# Altium schematic sheet JacdacServoDual 23.SchDoc
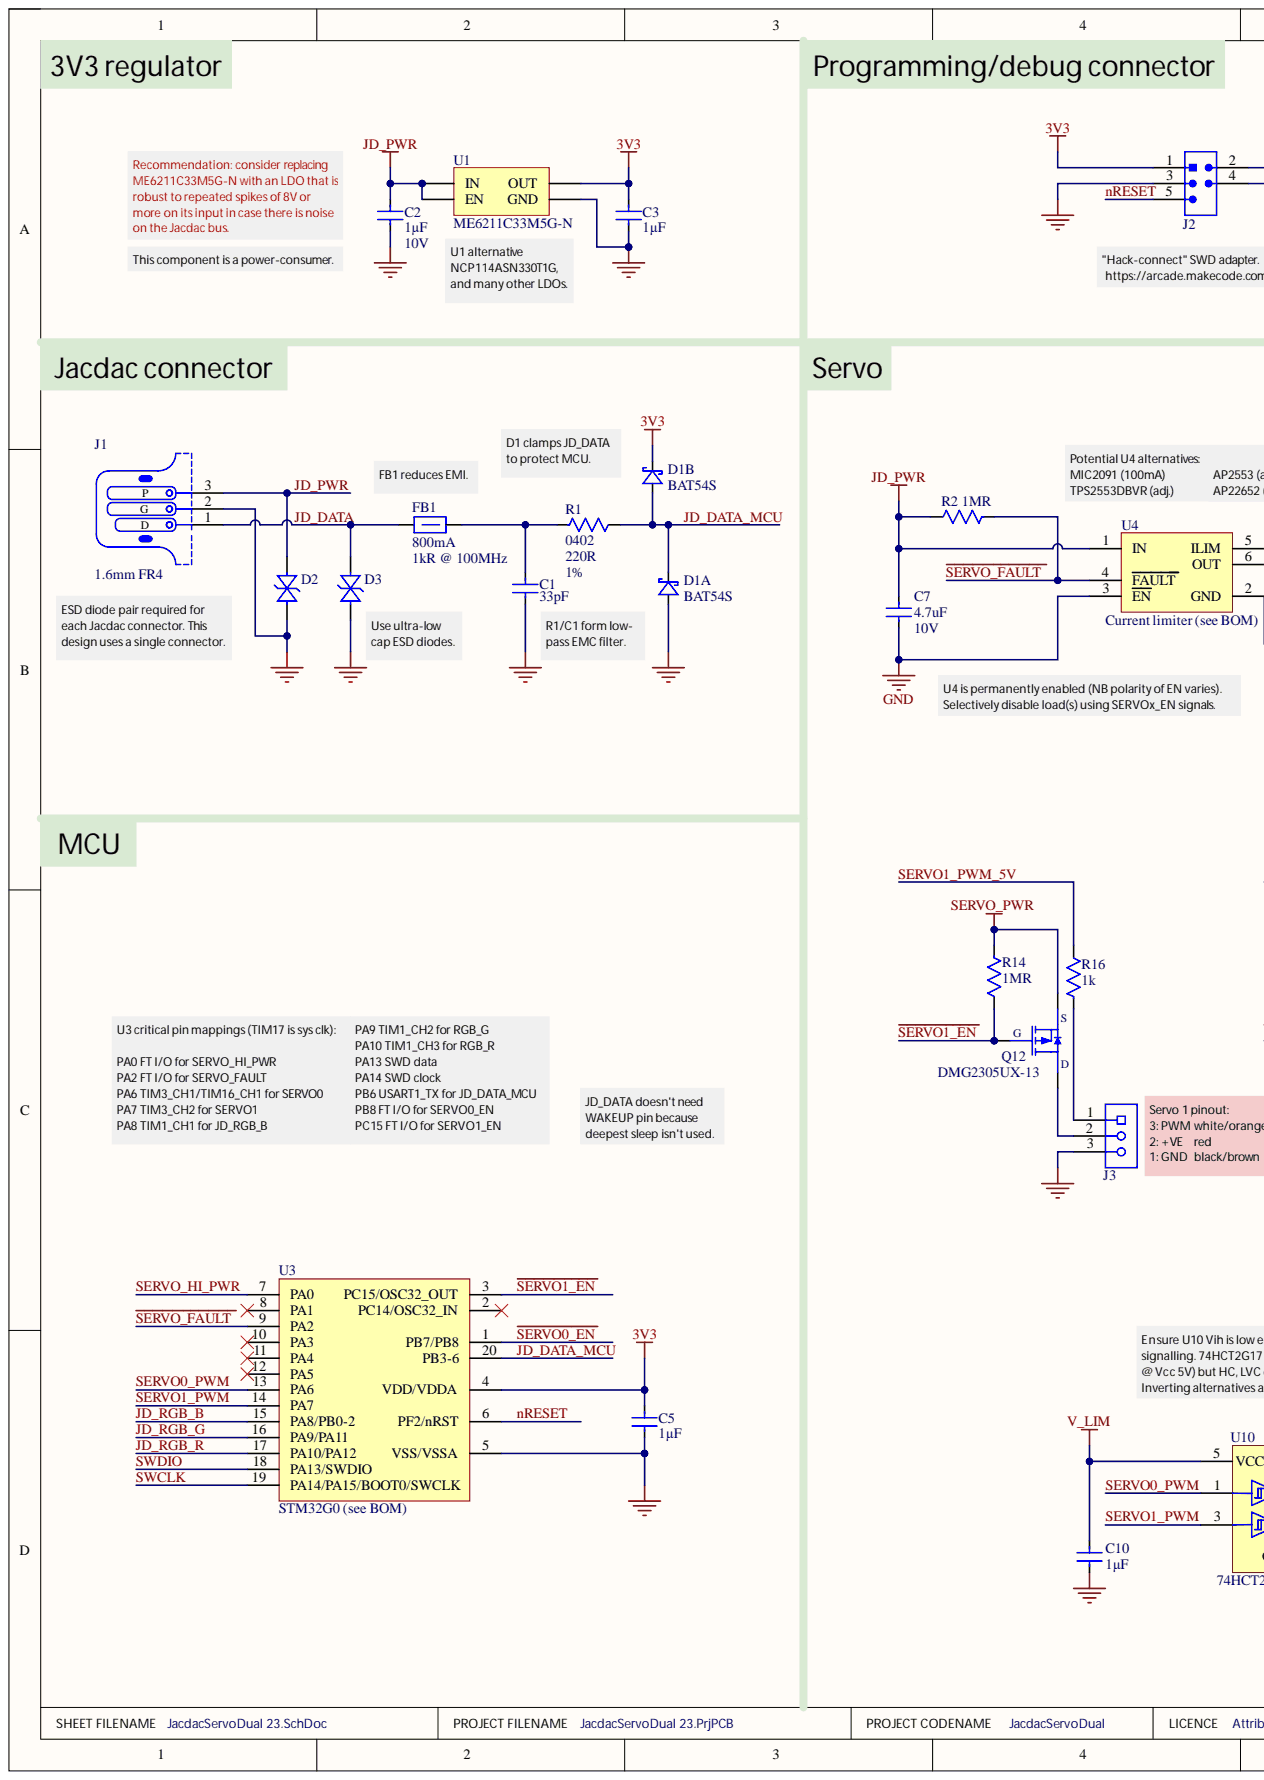
[diagram p0: JacdacServoDual 23.SchDoc, left]
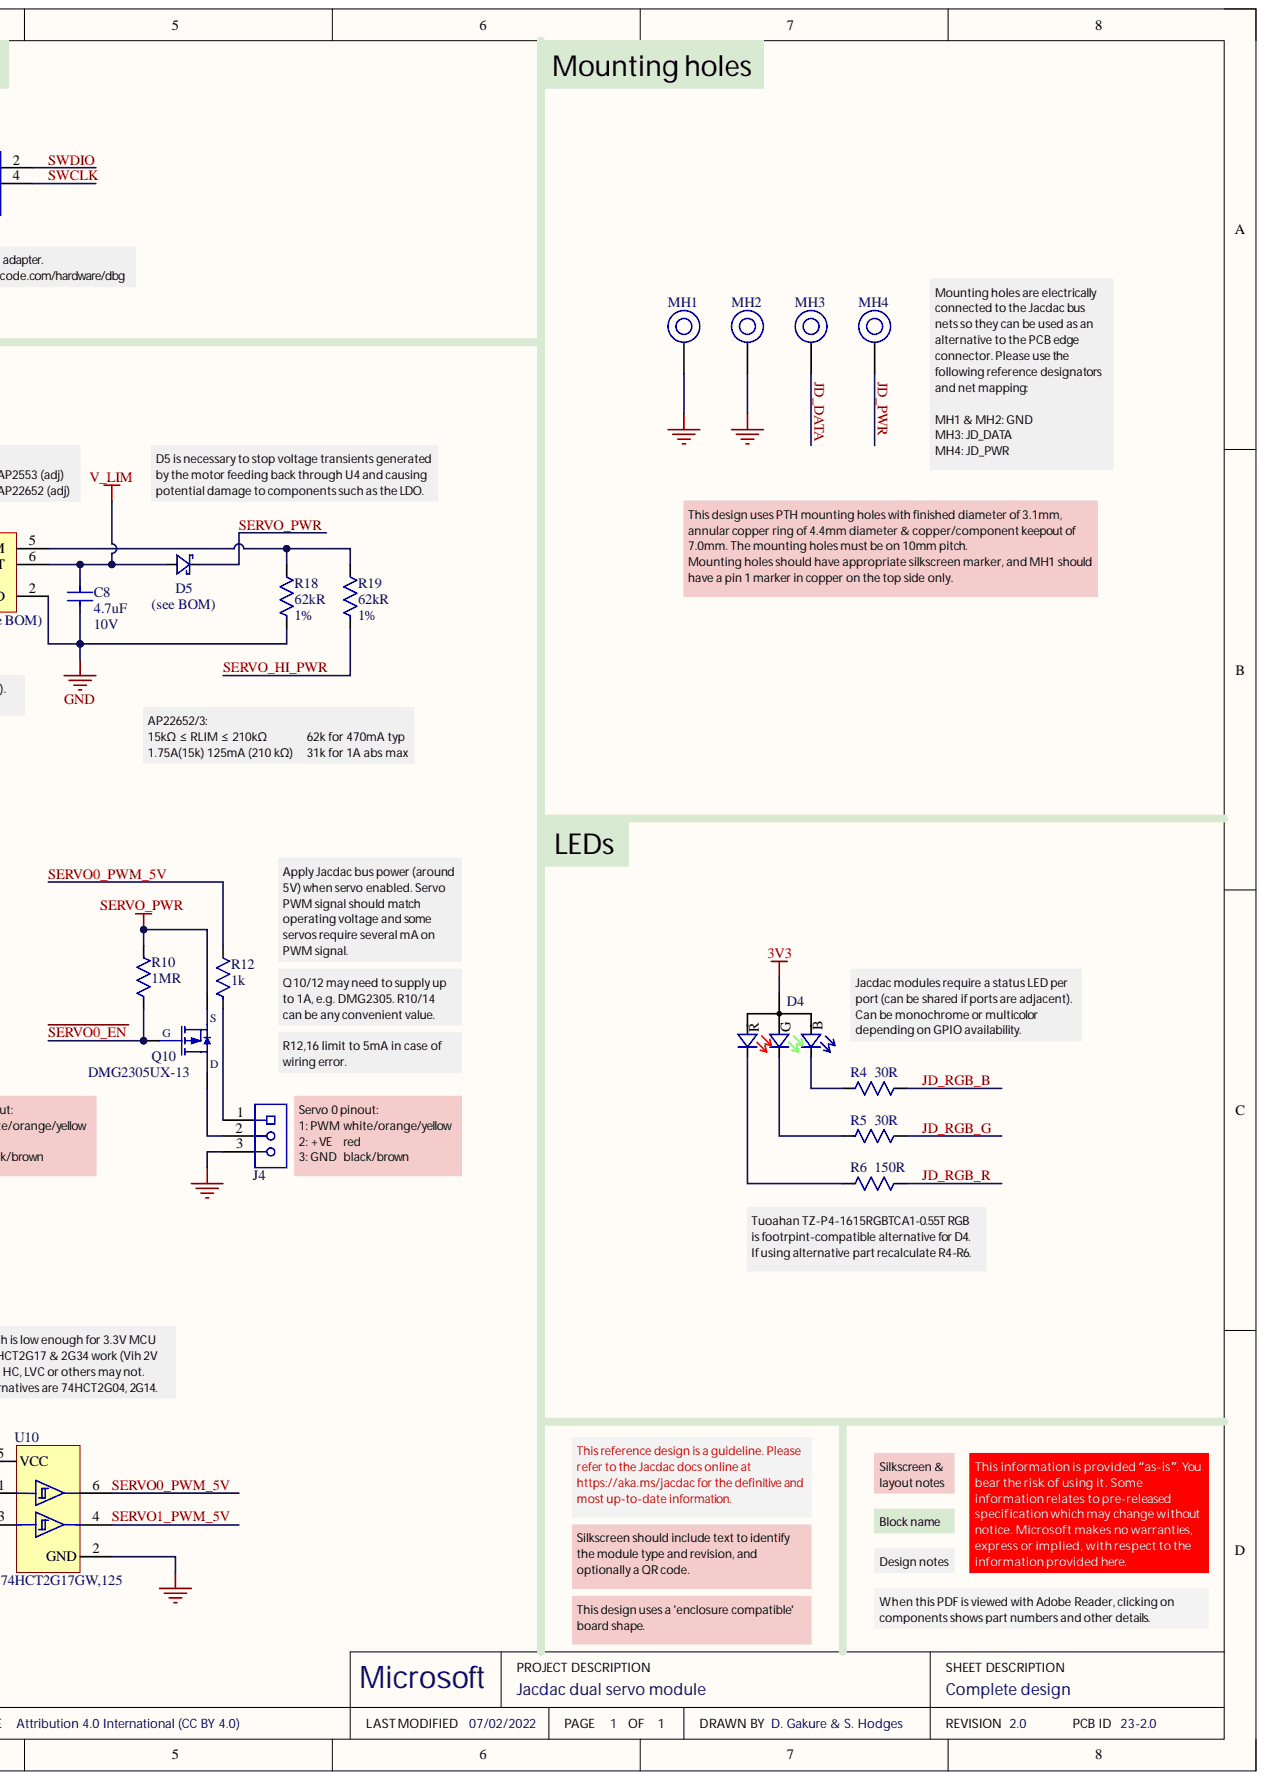
[diagram p1: JacdacServoDual 23.SchDoc, right]

## Parts
C1  Capacitance: 33pF
C2  Capacitance: 1µF  Voltage Rating: 10V
C3  Capacitance: 1µF
C5  Capacitance: 1µF
C7  Capacitance: 4.7uF  Voltage Rating: 10V
C8  Capacitance: 4.7uF  Voltage Rating: 10V
C10  Capacitance: 1µF
D1  Generic Part Number: BAT54S  (parts A,B)
D2  (no value)
D3  (no value)
D4  (no value)
D5  Generic Part Number: (see BOM)
FB1  Current Rating: 800mA
J1  PCB Type: 1.6mm FR4
J2  (no value)
J3  (no value)
J4  (no value)
MH1  (no value)
MH2  (no value)
MH3  (no value)
MH4  (no value)
Q10  Manufacturer Part Number: DMG2305UX-13
Q12  Manufacturer Part Number: DMG2305UX-13
R1  Case Code (Imperial): 0402  Resistance: 220R
R2  Resistance: 1MR
R4  Resistance: 30R
R5  Resistance: 30R
R6  Resistance: 150R
R10  Resistance: 1MR
R12  Resistance: 1k
R14  Resistance: 1MR
R16  Resistance: 1k
R18  Resistance: 62kR  Resistance Tolerance: 1%
R19  Resistance: 62kR  Resistance Tolerance: 1%
U1  Manufacturer Part Number: ME6211C33M5G-N
U3  Generic Part Number: STM32G0 (see BOM)
U4  Generic Part Number: Current limiter (see BOM)
U10  Manufacturer Part Number 1: 74HCT2G17GW,125

## Nets
3V3: C3.2 C5.2 D1.2 D4.1 J2.1 U1.5(OUT) U3.4(VDD/VDDA)
GND: C1.1 C2.1 C3.1 C5.1 C7.1 C8.1 C10.1 D1.1 D2.2 D3.2 J1.2 J2.3 J3.3 J4.3 MH1.1 MH2.1 R18.1 U1.2(GND) U3.5(VSS/VSSA) U4.2(GND) U4.3(EN) U10.2(GND)
V_LIM: C8.2 C10.2 D5.2 U4.6(OUT) U10.5(VCC)
~{SERVO0_EN}: Q10.1 R10.1 U3.1(PB7/PB8)
~{SERVO1_EN}: Q12.1 R14.1 U3.3(PC15/OSC32_OUT)
~{SERVO_FAULT}: R2.2 U3.9(PA2) U4.4(FAULT)
JD_DATA: D3.1 FB1.1 J1.1 MH3.1
JD_DATA_MCU: D1.3 R1.1 U3.20(PB3-6)
JD_PWR: C2.2 C7.2 D2.1 J1.3 MH4.1 R2.1 U1.1(IN) U1.3(EN) U4.1(IN)
JD_RGB_B: R4.2 U3.15(PA8/PB0-2)
JD_RGB_G: R5.2 U3.16(PA9/PA11)
JD_RGB_R: R6.2 U3.17(PA10/PA12)
nRESET: J2.5 U3.6(PF2/nRST)
SERVO0_PWM: U3.13(PA6) U10.1
SERVO0_PWM_5V: R12.1 U10.6
SERVO1_PWM: U3.14(PA7) U10.3
SERVO1_PWM_5V: R16.1 U10.4
SERVO_HI_PWR: R19.1 U3.7(PA0)
SERVO_PWR: D5.1 Q10.2 Q12.2 R10.2 R14.2
SWCLK: J2.4 U3.19(PA14/PA15/BOOT0/SWCLK)
SWDIO: J2.2 U3.18(PA13/SWDIO)
NetC1_2: C1.2 FB1.2 R1.2
NetD4_2: D4.2 R6.1
NetD4_3: D4.3 R5.1
NetD4_4: D4.4 R4.1
NetJ3_1: J3.1 R16.2
NetJ3_2: J3.2 Q12.3
NetJ4_1: J4.1 R12.2
NetJ4_2: J4.2 Q10.3
NetR18_2: R18.2 R19.2 U4.5(ILIM)

Not connected: U3 2(PC14/OSC32_IN) 8(PA1) 10(PA3) 11(PA4) 12(PA5)

## Library data of the parts
C1 = (no symbol name): CAP CER 33PF 50V C0G/NP0 0402; Manufacturer: Samsung; Manufacturer Part Number: CL05C330JB5NNNC; Dielectric: C0G; Material: Ceramic; Temperature Coefficient: 300ppm/°C; Tolerance: 5%; Case Code (Imperial): 0402; Case Code (Metric): 1005; Case/Package: 0402; Category: Ceramic Capacitors; ComponentLink1Description: Online datasheet; ComponentLink1URL: https://datasheet.ciiva.com/15195/getdatasheetpartid-355282-15195687.pdf; Construction: Flat; Contact Plating: Tin; Max Operating Temperature: 125°C; Min Operating Temperature: -55°C; RoHS Compliant: Yes; Series: CL; Supplier 1: Digi-Key; Supplier 2: Newark; Supplier 3: Mouser; Supplier 4: Farnell; Supplier Part Number 1: 1276-1272-1-ND; Supplier Part Number 2: 82AC9259; Supplier Part Number 3: 187-CL05C330JB5NNNC; Supplier Part Number 4: 3013373RL; Voltage: 50V; Voltage Rating: 50V; Voltage Rating (DC): 50V
C2 C3 C5 C10 = (no symbol name): CAP CER 1UF 10V X5R 0402; Manufacturer: Samsung; Manufacturer Part Number: CL05A105KP5NNNC; Material: Ceramic; Tolerance: 10%; Case Code (Imperial): 0402; Case Code (Metric): 1005; Case/Package: 0402; Category: Capacitors; ComponentLink1Description: Online datasheet; ComponentLink1URL: https://datasheet.ciiva.com/15195/getdatasheetpartid-358390-15195630.pdf; Contact Plating: Tin; Dielectric: X5R; Max Operating Temperature: 85°C; Min Operating Temperature: -55°C; Supplier 1: Digi-Key; Supplier 2: Mouser; Supplier 3: Farnell; Supplier Part Number 1: 1276-1076-1-ND; Supplier Part Number 2: 187-CL05A105KP5NNNC; Supplier Part Number 3: 3013329
  C3 C5 C10: Voltage Rating: 10V
C7 C8 = (no symbol name): CAP CER 4.7UF 10V X5R 0402; Manufacturer: Samsung; Manufacturer Part Number: CL05A475MP5NRNC; Tolerance: 20%; Case Code (Imperial): 0402; Case Code (Metric): 1005; Case/Package: 0402; Category: Ceramic Capacitors; ComponentLink1Description: Online datasheet; ComponentLink1URL: https://datasheet.ciiva.com/25786/cl05a475mp5nrnc-25786963.pdf; Dielectric: X5R; Max Operating Temperature: 85°C; Min Operating Temperature: -55°C; RoHS Compliant: Yes; Series: CL; Supplier 1: Digi-Key; Supplier 2: Future Electronics; Supplier Part Number 1: 1276-1482-2-ND; Supplier Part Number 2: 2103694
D1 = (no symbol name): DIODE ARRAY SCHOTTKY 30V SOT23; Manufacturer: Nexperia; Manufacturer Part Number: BAT54S,235; Category: Rectifier Diodes; ComponentLink1Description: NXP datasheet online; ComponentLink1URL: https://assets.nexperia.com/documents/data-sheet/BAT54_SER.pdf; Supplier 1: Digi-Key; Supplier 2: Mouser; Supplier 3: RSComponents; Supplier Part Number 1: 1727-1868-1-ND; Supplier Part Number 2: 771-BAT54S235; Supplier Part Number 3: 1707990
D2 D3 = (no symbol name): Bidirectional 5V (Max) 6V 16V 3.5A (8/20us) 0402 TVS RoHS; Manufacturer: Born Semiconductor; Manufacturer Part Number: RCLAMP0521P-N; ComponentLink1Description: Online datasheet; ComponentLink1URL: https://datasheet.lcsc.com/szlcsc/Bourne-Semicon-Shenzhen-RCLAMP0521P-N_C316043.pdf; Package: 0402; Supplier1: LCSC; Supplier1 Part Number: C316043
D4 = (no symbol name): Red, Green, Blue (RGB) 626nm Red, 525nm Green, 470nm Blue LED Indication - Discrete 1.9V Red, 3.4V Green, 3.4V Blue 0606 (1616 Metric); Manufacturer: Broadcom Avago; Manufacturer Part Number: HSMF-C114; Color: Blue,Green,Red; Forward Current: 20mA; Lens Color: White; Lens Style: Diffused,Rectangular; Lens Transparency: Diffused; Luminous Intensity: 70mcd; Number of Elements: 3; Power Rating: 78mW; Reverse Voltage: 5V; Viewing Angle: 145°; Case Code (Imperial): 0606; Case Code (Metric): 1616; Case/Package: 0606; Category: Optoelectronics; ComponentLink1Description: Online datasheet; ComponentLink1URL: https://docs.broadcom.com/docs/AV02-2451EN; Dominant Wavelength: 470nm; Forward Voltage: 3.4V; Max Operating Temperature: 85°C; Min Operating Temperature: -40°C; Number of LEDs: 3; Number of Pins: 4; Supplier 1: Digi-Key; Supplier 2: Farnell; Supplier 3: Mouser; Supplier Part Number 1: 516-1795-1-ND; Supplier Part Number 2: 1058362; Supplier Part Number 3: 630-HSMF-C114; Supplier Part Number 10: ?; Test Current: 20mA; Wavelength: 470nm
D5 = (no symbol name): DIODE SCHOTTKY 20V 1A SOD123FL; Manufacturer: ON Semiconductor; Manufacturer Part Number: MBR120VLSFT3G; Element Configuration: Single; Forward Voltage: 340mV; Max Forward Surge Current (Ifsm): 45A; Max Reverse Leakage Current: 600uA; Polarity: Standard; Average Rectified Current: 1A; Category: Schottky Diodes; ComponentLink4Description: On Semi datasheet online; ComponentLink4URL: https://datasheet.ciiva.com/6380/mbr120vlsft1-d-6380910.pdf; Current Rating: 1A; Forward Current: 1A; Halogen Free: Halogen Free; Max Operating Temperature: 125°C; Max Repetitive Reverse Voltage (Vrrm): 20V; Max Reverse Voltage (DC): 20V; Max Surge Current: 45A; Min Operating Temperature: -65°C; Number of Pins: 2; Output Current: 1A; Peak Non-Repetitive Surge Current: 45A; Peak Reverse Current: 600uA; Supplier 1: Digi-Key; Supplier 2: Mouser; Supplier 3: RSComponents; Supplier Part Number 1: MBR120VLSFT3GOSCT-ND; Supplier Part Number 2: 863-MBR120VLSFT3G; Supplier Part Number 3: 1867479; Voltage Rating (DC): 20V
FB1 = (no symbol name): 0603 1k@100MHz 0.8A chip; Manufacturer: TDK; Manufacturer Part Number: MPZ1608S102ATA00; Core Material: Ferrite; DC Resistance (DCR): 300mR; Impedance: 1kR @ 100MHz; Tolerance: 25%; Case Code (Imperial): 0603; Case Code (Metric): 1608; Case/Package: 0603; ComponentLink2Description: datasheet online; ComponentLink2URL: https://www.mouser.co.uk/datasheet/2/400/eads_commercial_power_mpz1608_en-923650.pdf; ComponentLink3Description: datasheet; Max DC Current: 800mA; Supplier 1: Digi-Key; Supplier 2: Mouser; Supplier Part Number 1: 445-8694-1-ND; Supplier Part Number 2: 810-MPZ1608S102ATA00; ComponentLink3URL: Y:\System\Altium\Libraries 2014\TDK\Datasheets\eads_commercial_power_mpz1608_en-923650.pdf
J1 = (no symbol name): 3 pin Jacdac edge connector 1.27mm pitch; Manufacturer Part Number: JACDAC_EDGE_CONNECTOR; ComponentLink1Description: Yuliang JACDAC cable connector drawing online; ComponentLink1URL: https://github.com/microsoft/jacdac-mdk/blob/main/connector/JACDAC-Connector-by-Yuliang-2020-11-28.pdf; ComponentLink2Description: JACDAC PCB edge connector spec onlin; ComponentLink2URL: https://github.com/microsoft/jacdac-mdk/blob/main/connector/JACDAC_PCB_Edge_Connector_Drawing_JD-PEC-01_rev_01.pdf
J2 = (no symbol name): Hack Connect Mini 1.27 mm pitch 2-row
J3 J4 = (no symbol name): SAMTEC         TSM-103-01-L-SH-A             Board-To-Board Connector, TSM Series, 3 Contacts, Header, 2.54 mm, Surface Mount, 1 Rows; Gender: Male; Number of Contacts: 3; Pitch: 2.54mm; ComponentLink2Description: part of technical drawing online; ComponentLink2URL: https://datasheet.ciiva.com/25616/0900766b8115801b-25616876.pdf; ComponentLink3Description: part of technical drawing; ComponentLink4Description: samtec datasheet; ComponentLink5Description: samtec datasheet online; ComponentLink5URL: https://uk.rs-online.com/webdocs/1157/0900766b81157e6c.pdf?src-supplier=RSComponents; Contact Plating: Gold; Manufacturer: Samtec; Manufacturer Part Number: TSM-103-01-L-SH-A; Number of Rows: 1; Supplier 1: Digi-Key; Supplier 2: RSComponents; Supplier 3: Mouser; Supplier Part Number 1: SAM12221-ND; Supplier Part Number 2: 2001543; Supplier Part Number 3: 200-TSM10301LSHA; Termination: Solder; ComponentLink3URL: Y:\System\Altium\Libraries 2014\Samtec\Datasheets\TSM drawing.pdf; ComponentLink4URL: Y:\System\Altium\Libraries 2014\Samtec\Datasheets\tsm.pdf
MH1-MH4 = (no symbol name): Mounting Hole 7.0mm keepout, 4.4mm annualar ring and 3.1mm Drill PTH
Q10 Q12 = (no symbol name): MOSFET P-CH 20V 4.2A SOT23; Manufacturer: Diodes; Drain to Source Breakdown Voltage: -20V; Drain to Source Resistance: 52mR; Element Configuration: Single; Fall Time: 34.7ns; Gate to Source Voltage (Vgs): 8V; Input Capacitance: 808pF; Max Power Dissipation: 1.4W; Number of Channels: 1; On-State Resistance: 65MR; Rise Time: 13.7ns; Threshold Voltage: -900mV; Turn-Off Delay Time: 79.3ns; Turn-On Delay Time: 10.8ns; Case/Package: TO-236-3; ComponentLink2Description: online datasheet; ComponentLink2URL: https://datasheet.ciiva.com/18566/dmg2305ux-18566951.pdf; ComponentLink3Description: datasheet; Continuous Drain Current (ID): 4.2A; Drain to Source Voltage (Vdss): 20V; Max Operating Temperature: 150°C; Min Operating Temperature: -55°C; Number of Pins: 3; Rds On Max: 52mR; Supplier 1: Digi-Key; Supplier 2: Farnell; Supplier 3: Mouser; Supplier 4: RSComponents; Supplier Part Number 1: DMG2305UX-13DICT-ND; Supplier Part Number 2: 2543533; Supplier Part Number 3: 621-DMG2305UX-7; Supplier Part Number 4: 8270452; Weight: 0.000282oz; ComponentLink3URL: Y:\System\Altium\Libraries 2014\Diodes Inc\Datasheets\dmg2305ux-30023840.pdf
R1 = (no symbol name): RES SMD 220 OHM 1% 1/16W 0402; Manufacturer: Yageo; Manufacturer Part Number: RC0402FR-07220RL; Max Power Dissipation: 63mW; Power Rating: 62.5mW; Temperature Coefficient: 100ppm/°C; Voltage Rating: 50V; Case Code (Metric): 1005; Case/Package: 0402; Category: Resistors; ComponentLink1Description: Online datasheet; ComponentLink1URL: https://datasheet.ciiva.com/11819/0900766b80c66297-11819466.pdf; Features: Moisture Resistant; Max Operating Temperature: 155°C; Min Operating Temperature: -55°C; Number of Pins: 2; Number of Terminations: 2; Resistor Type: Thick Film; Supplier 1: Digi-Key; Supplier 2: Mouser; Supplier 3: Farnell; Supplier Part Number 1: 311-220LRCT-ND; Supplier Part Number 2: 603-RC0402FR-07220RL; Supplier Part Number 3: 2147864; Termination: SMD/SMT; Tolerance: 1%; Voltage Rating (DC): 50V
R2 R10 R14 = (no symbol name): RES SMD 1M OHM 1% 1/16W 0402; Manufacturer: Yageo; Manufacturer Part Number: RC0402FR-071ML; Max Power Dissipation: 63mW; Temperature Coefficient: 100ppm/°C; Voltage Rating: 50V; Case Code (Imperial): 0402; Case Code (Metric): 1005; Case/Package: 0402; Category: Chip SMD Resistors; ComponentLink1Description: Online datasheet; ComponentLink1URL: https://datasheet.ciiva.com/11808/0900766b80c66297-11808125.pdf; Construction: Rectangular; Features: Moisture Resistant; Max Operating Temperature: 155°C; Min Operating Temperature: -55°C; Number of Terminations: 2; Power Rating: 63mW; Resistance Tolerance: 1%; Resistor Type: Thick Film; RoHS Compliant: Yes; Series: RC; Size Code: 0402; Supplier 1: Digi-Key; Supplier 2: Mouser; Supplier 3: Farnell; Supplier Part Number 1: 311-1.00MLRCT-ND; Supplier Part Number 2: 603-RC0402FR-071ML; Supplier Part Number 3: 2421892; Technology: THICK FILM; Termination: SMD/SMT; Tolerance: 1%
R4 R5 = (no symbol name): RES SMD 30 OHM 1% 1/16W 0402; Manufacturer: Yageo; Manufacturer Part Number: RC0402FR-0730RL; Max Power Dissipation: 63mW; Temperature Coefficient: 100ppm/°C; Voltage Rating: 50V; Case Code (Imperial): 0402; Case Code (Metric): 1005; Case/Package: 0402; Category: Chip SMD Resistors; ComponentLink1Description: Online datasheet; ComponentLink1URL: https://datasheet.ciiva.com/7849/1018594-7849958.pdf; Construction: Rectangular; Contact Plating: Tin,Matte; Features: Moisture Resistant; Max Operating Temperature: 155°C; Min Operating Temperature: -55°C; Number of Terminations: 2; Power Rating: 63mW; Resistance Tolerance: 1%; Resistor Type: Thick Film; RoHS Compliant: Yes; Series: RC; Size Code: 0402; Supplier 1: Digi-Key; Supplier 2: Mouser; Supplier 3: Farnell; Supplier Part Number 1: 311-30LRCT-ND; Supplier Part Number 2: 603-RC0402FR-0730RL; Supplier Part Number 3: 1458775; Termination: SMD/SMT; Tolerance: 1%; Voltage Rating (DC): 50V
R6 = (no symbol name): RES SMD 150 OHM 1% 1/16W 0402; Manufacturer: Yageo; Manufacturer Part Number: RC0402FR-07150RL; Max Power Dissipation: 63mW; Power Rating: 62.5mW; Temperature Coefficient: 100ppm/°C; Voltage Rating: 50V; Case Code (Imperial): 0402; Case Code (Metric): 1005; Case/Package: 0402; Category: Chip SMD Resistors; ComponentLink1Description: ine datasheet; ComponentLink1URL: https://datasheet.ciiva.com/11808/0900766b80c66297-11808422.pdf; Construction: Rectangular; Features: Moisture Resistant; Max Operating Temperature: 155°C; Min Operating Temperature: -55°C; Number of Terminations: 2; Resistance Tolerance: 1%; Resistor Type: Thick Film; RoHS Compliant: Yes; Series: RC; Supplier 1: Digi-Key; Supplier 2: Mouser; Supplier 3: Farnell; Supplier Part Number 1: 311-150LRCT-ND; Supplier Part Number 2: 603-RC0402FR-07150RL; Supplier Part Number 3: 2421893; Technology: THICK FILM; Termination: SMD/SMT; Tolerance: 1%; Working Voltage: 50V
R12 R16 = (no symbol name): RES SMD 1K OHM 1% 1/16W 0402; Manufacturer: Yageo; Manufacturer Part Number: RC0402FR-071KL; Power Rating: 62.5mW; Temperature Coefficient: 100ppm/°C; Voltage Rating: 50V; Case Code (Imperial): 0402; Case Code (Metric): 1005; Case/Package: 0402; Category: Resistors; ComponentLink2Description: Online datasheet; ComponentLink2URL: https://datasheet.ciiva.com/12666/pyu-rc0402-51-rohs-l-6-r-12666741.pdf; Max Operating Temperature: 155°C; Min Operating Temperature: -55°C; Number of Pins: 2; Resistor Type: Thick Film; Supplier 1: Digi-Key; Supplier 2: Mouser; Supplier 3: Farnell; Supplier Part Number 1: 311-1.00KLRDKR-ND; Supplier Part Number 2: 603-RC0402FR-071KL; Supplier Part Number 3: 2132441; Termination: SMD/SMT; Tolerance: 1%; Voltage Rating (DC): 50V
R18 R19 = (no symbol name): RES SMD 62K OHM 1% 1/16W 0402; Manufacturer 1: Yageo; Manufacturer Part Number 1: RC0402FR-0762KL; Max Power Dissipation: 63mW; Temperature Coefficient: 100ppm/°C; Voltage Rating: 50V; Case Code (Imperial): 0402; Case Code (Metric): 1005; Case/Package: 0402; Category: Chip SMD Resistors; ComponentLink1Description: Online datasheet; ComponentLink1URL: https://datasheet.ciiva.com/17068/pyu-rc0402-51-rohs-l-6-r-17068614.pdf; Construction: Rectangular; Features: Moisture Resistant; Max Operating Temperature: 155°C; Min Operating Temperature: -55°C; Number of Terminations: 2; Power Rating: 63mW; Resistor Type: Thick Film; RoHS Compliant: Yes; Series: RC; Supplier 1: Digi-Key; Supplier 2: Farnell; Supplier 3: RSComponents; Supplier Part Number 1: 311-62.0KLRCT-ND; Supplier Part Number 2: 1458817; Supplier Part Number 3: 1987847; Technology: THICK FILM; Termination: SMD/SMT; Tolerance: 1%; Voltage Rating (DC): 50V; Working Voltage: 50V
U1 = (no symbol name): 6V 260mV @ 200mA(typ) - - Fixed 3.3V SOT-23-5 Linear Voltage Regulators (LDO) ROHS; manufacturer: MICRONE(Nanjing Micro One Elec); Dropout Voltage: 200mV; Max Input Voltage: 6; Number of Regulators: 1; Output Configuration: Positive; Output Current: 500mA; Case/Package: SOT-23-5; Category: Voltage Regulators - Linear; ComponentLink3Description: On Semi datasheet online; ComponentLink3URL: https://datasheet.lcsc.com/lcsc/1811131510_MICRONE-Nanjing-Micro-One-Elec-ME6211C33M5G-N_C82942.pdf; Min Output Voltage: 3.3V; Output Type: Fixed; Supplier 1: LCSC; Supplier Part Number: C82942
U3 = (no symbol name): MCU 32-Bit STM32G031 ARM Cortex-M0+ RISC 32KB Flash 1.7V to 3.6V 20-Pin TSSOP Tube; Manufacturer: STMicroelectronics; Manufacturer Part Number: STM32G031F6P6; Category: Microcontrollers; ComponentLink1Description: Online datasheet; ComponentLink1URL: https://www.st.com/resource/en/datasheet/stm32g031c6.pdf; ComponentLink2Description: Online reference manual; ComponentLink2URL: https://www.st.com/resource/en/reference_manual/rm0454-stm32g0x0-advanced-armbased-32bit-mcus-stmicroelectronics.pdf; Supplier 1: Digi-Key; Supplier 2: Farnell; Supplier 3: RSComponents; Supplier Part Number 1: 497-19774-ND; Supplier Part Number 2: 3246918; Supplier Part Number 3: 1939834
U4 = (no symbol name): USB Power High Side Switch Single 3V to 5.5V 2.1A 6-Pin SOT-26 T/R; Manufacturer 1: Diodes; Manufacturer Part Number 1: AP22652W6-7; Category: USB Interface ICs; ComponentLink1Description: Online datasheet; ComponentLink1URL: https://www.diodes.com/assets/Datasheets/AP22653Q.pdf; Supplier 1: Digi-Key; Supplier 2: Mouser; Supplier Part Number 1: 31-AP22652W6-7CT-ND; Supplier Part Number 2: 621-AP22652W6-7
U10 = (no symbol name): 74HCT2G17 Series 5.5 V 4 mA Dual Non-Inverting Schmitt Trigger - TSSOP-6; Manufacturer 1: Nexperia; Category: Logic Gates; ComponentLink1Description: Online datasheet; ComponentLink1URL: https://assets.nexperia.com/documents/data-sheet/74LVC2G17.pdf; ComponentLink2Description: https://assets.nexperia.com/documents/data-sheet/74HC_HCT2G17.pdf?src-supplier=Digi-Key; ComponentLink2URL: https://assets.nexperia.com/documents/data-sheet/74HC_HCT2G17.pdf?src-supplier=Digi-Key; RoHS Compliant: Yes; Supplier 1: Digi-Key; Supplier 2: Farnell; Supplier 3: Mouser; Supplier Part Number 1: 1727-6931-1-ND; Supplier Part Number 2: 2777768; Supplier Part Number 3: 771-HCT2G17GW125
